# Altium schematic sheet IMU.SchDoc
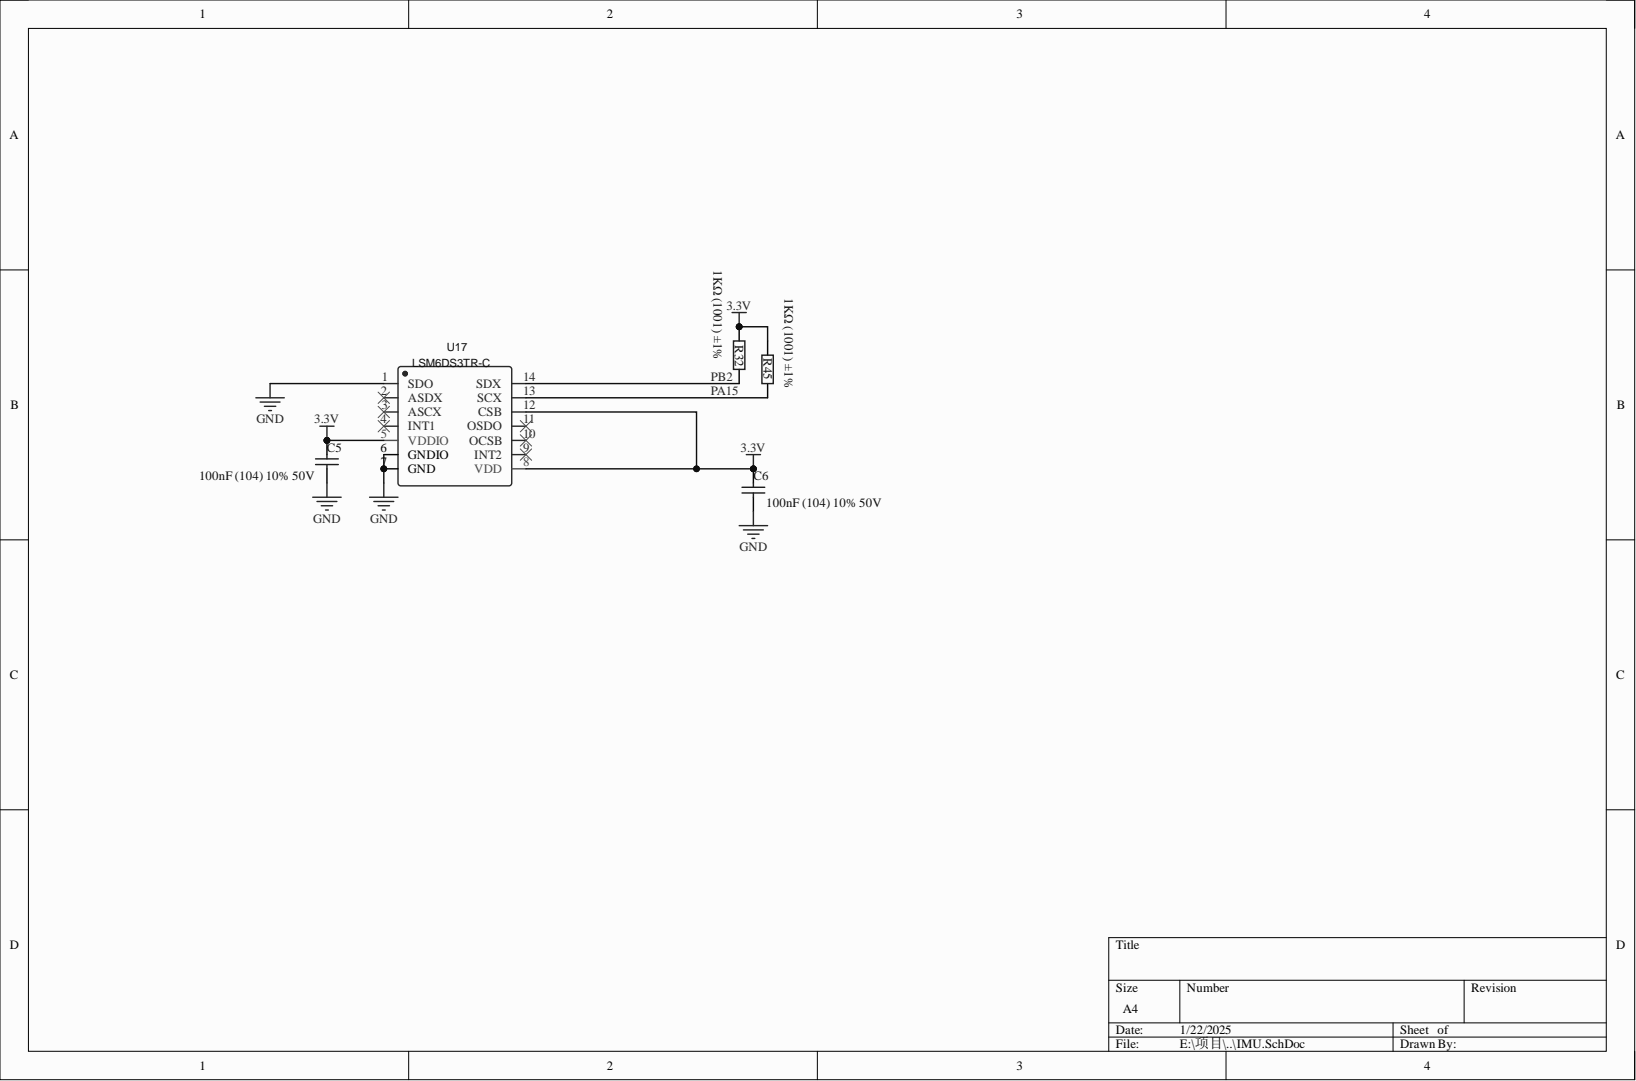
[diagram p0: IMU.SchDoc]

## Parts
C5  100nF (104) 10% 50V  [0603_C]
C6  100nF (104) 10% 50V  [0603_C]
R32  1KΩ (1001) ±1%  [0603_R]
R45  1KΩ (1001) ±1%  [0603_R]
U17  LSM6DS3TR-C  [LGA-14_L3.0-W2.5-P0.50-BL]

## Nets
3.3V: C5.1 C6.1 R32.2 R45.2 U17.5(VDDIO) U17.8(VDD) U17.12(CSB)
GND: C5.2 C6.2 U17.1(SDO) U17.6(GNDIO) U17.7(GND)
PA15: R45.1 U17.13(SCX)
PB2: R32.1 U17.14(SDX)

Not connected: U17 2(ASDX) 3(ASCX) 4(INT1) 9(INT2) 10(OCSB) 11(OSDO)

## Library data of the parts
C5 C6 = 0603 100nF (104) 10% 50V: 贴片电容; Component Kind: Standard; ComponentLink1Description: 供应商链接; ComponentLink1URL: http://www.szlcsc.com/product/details_15331.html; Library Name: Cap.SchLib; Package: 0603; Supplier: LC; SuppliersPartNumber: C14663
R32 R45 = 0402 1KΩ (1001) 1%: 贴片电阻; Component Kind: Standard; ComponentLink1Description: 供应商链接; ComponentLink1URL: http://www.szlcsc.com/product/details_12256.html; Library Name: RES.SchLib; Package: 0402; Supplier: LC; SuppliersPartNumber: C11702
U17 = BMI160: BOM_Manufacturer: Bosch; SMT Type: Extend; BOM_LCSC Assembly: Yes; BOM_Manufacturer Part: BMI160; BOM_Supplier: LCSC; BOM_Supplier Part: C94021; Component Kind: Standard; spicePre: U; spiceSymbolName: BMI160
